# Altium schematic sheet COM.SchDoc
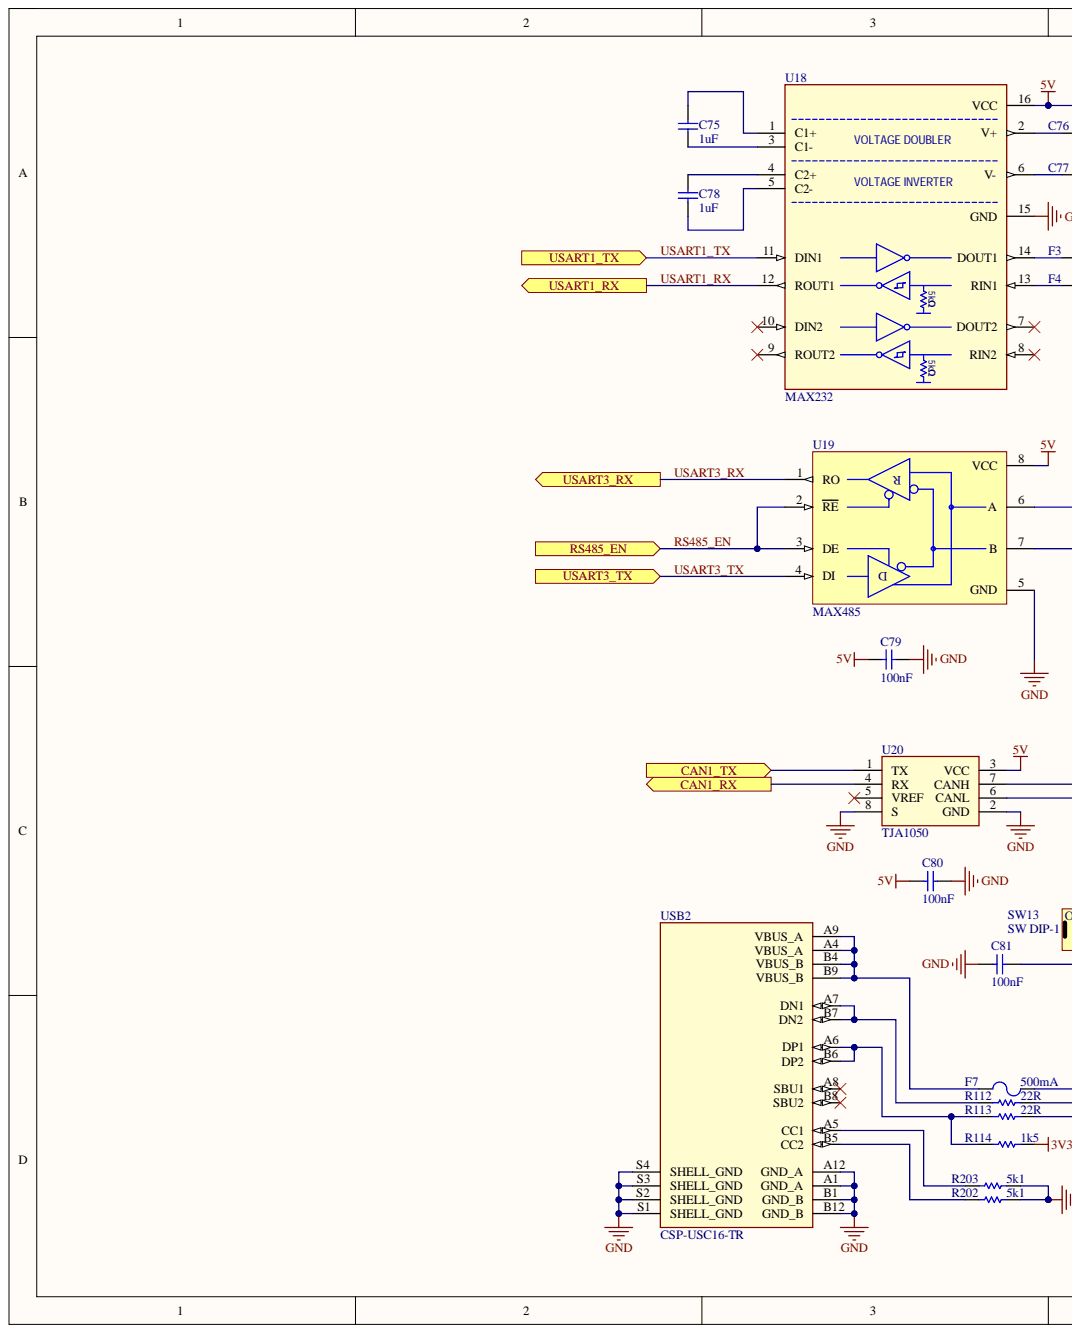
[diagram p0: COM.SchDoc, left]
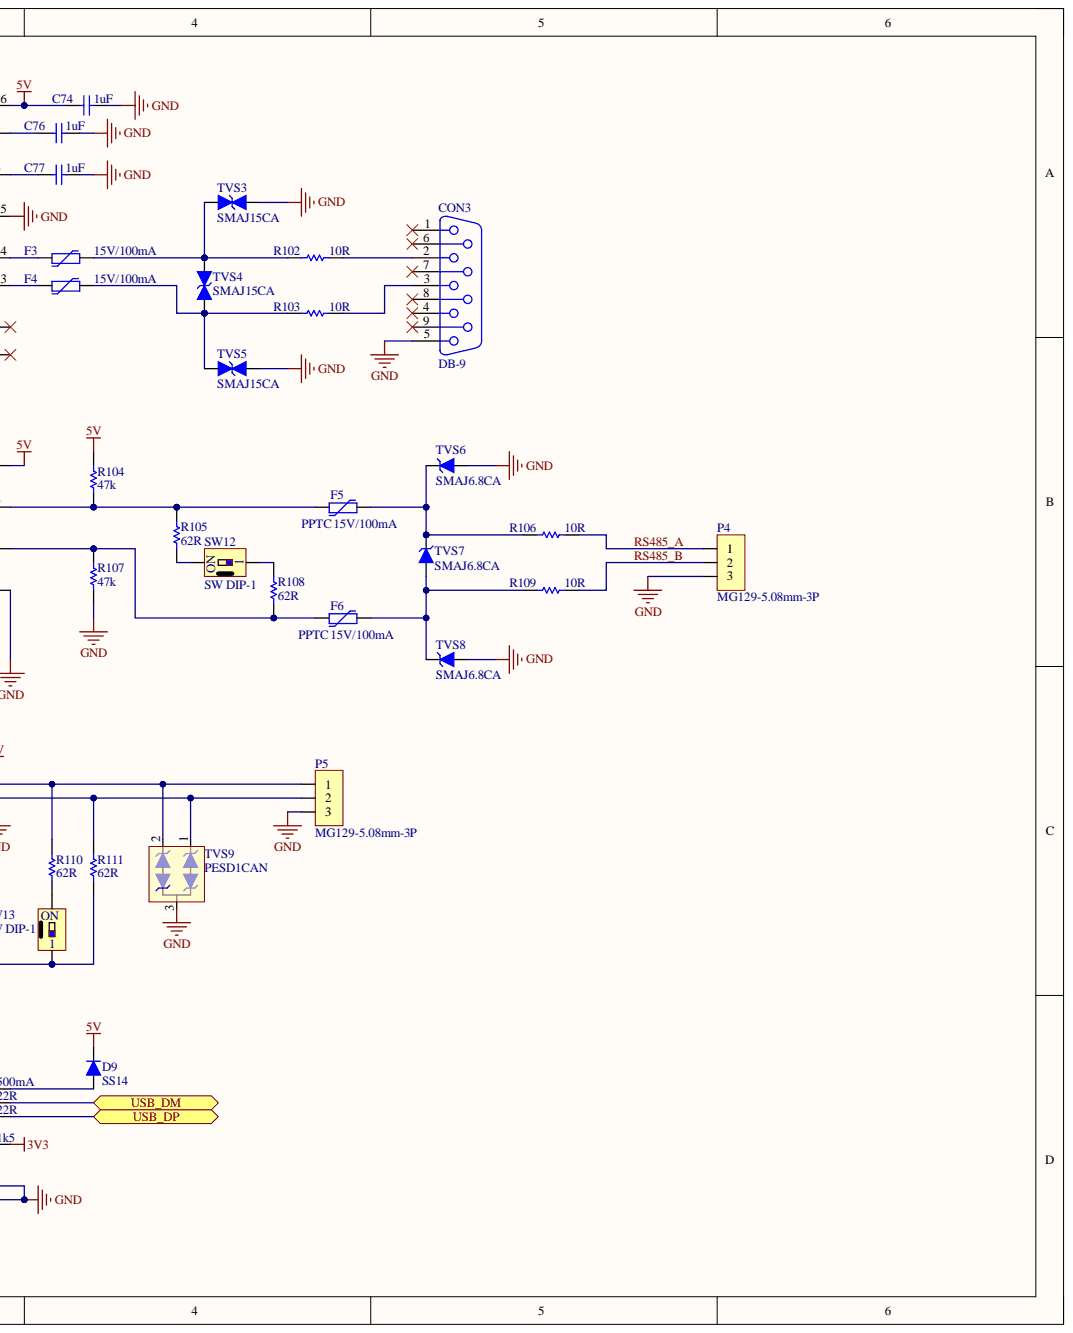
[diagram p1: COM.SchDoc, right]

## Parts
C74  1uF  [C 0603 (1608)]
C75  1uF  [C 0603 (1608)]
C76  1uF  [C 0603 (1608)]
C77  1uF  [C 0603 (1608)]
C78  1uF  [C 0603 (1608)]
C79  100nF  [C 0603 (1608)]
C80  100nF  [C 0603 (1608)]
C81  100nF  [C 0603 (1608)]
CON3  DB-9  [CON DB9 FEMALE ROTATY]
D9  SS14  [DO-214AC (SMA)]
F3  15V/100mA  [Fuse 0805 SMD]
F4  15V/100mA  [Fuse 0805 SMD]
F5  PPTC 15V/100mA  [Fuse 0805 SMD]
F6  PPTC 15V/100mA  [Fuse 0805 SMD]
F7  500mA  [Fuse 0805 SMD]
P4  MG129-5.08mm-3P  [HDR Domino 3 - 5MM]
P5  MG129-5.08mm-3P  [HDR Domino 3 - 5MM]
R102  10R  [R 0603 (1608)]
R103  10R  [R 0603 (1608)]
R104  47k  [R 0603 (1608)]
R105  62R  [R 0603 (1608)]
R106  10R  [R 0603 (1608)]
R107  47k  [R 0603 (1608)]
R108  62R  [R 0603 (1608)]
R109  10R  [R 0603 (1608)]
R110  62R  [R 0603 (1608)]
R111  62R  [R 0603 (1608)]
R112  22R  [R 0603 (1608)]
R113  22R  [R 0603 (1608)]
R114  1k5  [R 0603 (1608)]
R202  5k1  [R 0603 (1608)]
R203  5k1  [R 0603 (1608)]
SW12  SW DIP-1  [SW/DIP1]
SW13  SW DIP-1  [SW/DIP1]
TVS3  SMAJ15CA  [DO-214AC (SMA)]
TVS4  SMAJ15CA  [DO-214AC (SMA)]
TVS5  SMAJ15CA  [DO-214AC (SMA)]
TVS6  SMAJ6.8CA  [DO-214AC (SMA)]
TVS7  SMAJ6.8CA  [DO-214AC (SMA)]
TVS8  SMAJ6.8CA  [DO-214AC (SMA)]
TVS9  PESD1CAN  [SOT-23]
U18  MAX232  [SOIC-16]
U19  MAX485  [SOIC-8]
U20  TJA1050  [SOIC-8]
USB2  CSP-USC16-TR  [CSP-USC16-TR]

## Ports
CAN1_RX, CAN1_TX, RS485_EN, USART1_RX, USART1_TX, USART3_RX, USART3_TX, USB_DM, USB_DP

## Nets
3V3: R114.2
5V: C74.2 C79.1 C80.1 D9.2 R104.1 U18.16(VCC) U19.8(VCC) U20.3(VCC)
GND: C74.1 C76.1 C77.1 C79.2 C80.2 C81.1 CON3.5 P4.3 P5.3 R107.2 R202.2 R203.2 TVS3.1 TVS5.1 TVS6.1 TVS8.1 TVS9.3 U18.15(GND) U19.5(GND) U20.2(GND) U20.8(S) USB2.A1(GND_A) USB2.A12(GND_A) USB2.B1(GND_B) USB2.B12(GND_B) USB2.S1(SHELL_GND) USB2.S2(SHELL_GND) USB2.S3(SHELL_GND) USB2.S4(SHELL_GND)
CAN1_RX: U20.4(RX) ; port CAN1_RX
CAN1_TX: U20.1(TX) ; port CAN1_TX
RS485_A: P4.1 R106.2
RS485_B: P4.2 R109.2
RS485_EN: U19.2(RE) U19.3(DE) ; port RS485_EN
USART1_RX: U18.12(ROUT1) ; port USART1_RX
USART1_TX: U18.11(DIN1) ; port USART1_TX
USART3_RX: U19.1(RO) ; port USART3_RX
USART3_TX: U19.4(DI) ; port USART3_TX
USB_DM: R112.2 ; port USB_DM
USB_DP: R113.2 ; port USB_DP
NetC75_1: C75.1 U18.3(C1-)
NetC75_2: C75.2 U18.1(C1+)
NetC76_2: C76.2 U18.2(V+)
NetC77_2: C77.2 U18.6(V-)
NetC78_1: C78.1 U18.5(C2-)
NetC78_2: C78.2 U18.4(C2+)
NetC81_2: C81.2 R111.1 SW13.1
NetCON3_2: CON3.2 R102.2
NetCON3_3: CON3.3 R103.2
NetD9_1: D9.1 F7.2
NetF3_1: F3.1 U18.14(DOUT1)
NetF3_2: F3.2 R102.1 TVS3.2 TVS4.2
NetF4_1: F4.1 U18.13(RIN1)
NetF4_2: F4.2 R103.1 TVS4.1 TVS5.2
NetF5_1: F5.1 R104.2 R105.1 U19.6(A)
NetF5_2: F5.2 R106.1 TVS6.2 TVS7.2
NetF6_1: F6.1 R107.1 R108.2 U19.7(B)
NetF6_2: F6.2 R109.1 TVS7.1 TVS8.2
NetF7_1: F7.1 USB2.A4(VBUS_A) USB2.A9(VBUS_A) USB2.B4(VBUS_B) USB2.B9(VBUS_B)
NetP5_1: P5.1 R110.1 TVS9.2 U20.7(CANH)
NetP5_2: P5.2 R111.2 TVS9.1 U20.6(CANL)
NetR105_2: R105.2 SW12.2
NetR108_1: R108.1 SW12.1
NetR110_2: R110.2 SW13.2
NetR112_1: R112.1 USB2.A7(DN1) USB2.B7(DN2)
NetR113_1: R113.1 R114.1 USB2.A6(DP1) USB2.B6(DP2)
NetR202_1: R202.1 USB2.B5(CC2)
NetR203_1: R203.1 USB2.A5(CC1)

Not connected: CON3 1 4 6 7 8 9; U18 7(DOUT2) 8(RIN2) 9(ROUT2) 10(DIN2); U20 5(VREF); USB2 A8(SBU1) B8(SBU2)

## Library data of the parts
C74-C81 = Capacitor NP: Capacitor non-pol; Component Kind: Standard; Library Name: BKIT4U_LIB.SchLib
CON3 = CON DB-9: Connector D-Sub 9; Component Kind: Standard; Library Name: BKIT4U_LIB.SchLib
D9 = Diode: Default Diode; Code_JEDEC: DO-214-AB; Component Kind: Standard; LatestRevisionDate: 17-Jul-2002; LatestRevisionNote: Re-released for DXP Platform.; Library Name: BKIT4U_LIB.SchLib; PackageReference: SMC; PackageVersion: Sep-1996; Published: 8-Jun-2000; Publisher: Altium Limited
F3-F6 = PPTC Fuse: Component Kind: Standard; Library Name: BKIT4U_LIB.SchLib
F7 = Fuse: Component Kind: Standard; Description: Fuse; Library Name: BKIT4U_LIB.SchLib
P4 P5 = Header 3: Header, 3-Pin; Component Kind: Standard; Library Name: BKIT4U_LIB.SchLib
R102-R114 R202 R203 = Resistor: Component Kind: Standard; Description: Resistor; LatestRevisionDate: 17-Jul-2002; LatestRevisionNote: Re-released for DXP Platform.; Library Name: BKIT4U_LIB.SchLib; PackageReference: AXIAL-0.3; Published: 8-Jun-2000; Publisher: Altium Limited
TVS3-TVS5 = Diode TVS: Code_JEDEC: DO-214-AB; Component Kind: Standard; LatestRevisionDate: 17-Jul-2002; LatestRevisionNote: Re-released for DXP Platform.; Library Name: BKIT4U_LIB.SchLib; PackageReference: SMC; PackageVersion: Sep-1996; Published: 8-Jun-2000; Publisher: Altium Limited
TVS6-TVS8 = Diode Zener: Zener Diode; Component Kind: Standard; LatestRevisionDate: 17-Jul-2002; LatestRevisionNote: Re-released for DXP Platform.; Library Name: BKIT4U_LIB.SchLib; PackageReference: DIODE-0.7; Published: 8-Jun-2000; Publisher: Altium Limited
TVS9 = SM712: Code_JEDEC: DO-214-AB; Component Kind: Standard; LatestRevisionDate: 17-Jul-2002; LatestRevisionNote: Re-released for DXP Platform.; Library Name: BKIT4U_LIB.SchLib; PackageReference: SMC; PackageVersion: Sep-1996; Published: 8-Jun-2000; Publisher: Altium Limited
U18 = MAX232: RS-232 Line Driver/Receiver; Component Kind: Standard; Library Name: BKIT4U_LIB.SchLib
U20 = TJA1050: High speed CAN transceiver; Component Kind: Standard; Library Name: BKIT4U_LIB.SchLib
USB2 = CSP-USC16-TR: CSP-USC16-TR Valcon USB Type C Surface Mount PCB Socket; Availability: In Stock; Check_prices: https://www.snapeda.com/parts/CSP-USC16-TR/Valcon/view-part/?ref=eda; Component Kind: Standard; Library Name: BKIT4U_LIB.SchLib; MF: Valcon; MP: CSP-USC16-TR; Package: None; Price: None; Purchase-URL: https://pricing.snapeda.com/search/part/CSP-USC16-TR/?ref=eda; SnapEDA_Link: https://www.snapeda.com/parts/CSP-USC16-TR/Valcon/view-part/?ref=snap
SW12 SW13 = SW DIP-1: Component Kind: Standard; Library Name: BKIT4U_LIB.SchLib
U19 = MAX485: Component Kind: Standard; Library Name: BKIT4U_LIB.SchLib
